# Altium schematic sheet I2C_Keypad_host_module_HID.SchDoc
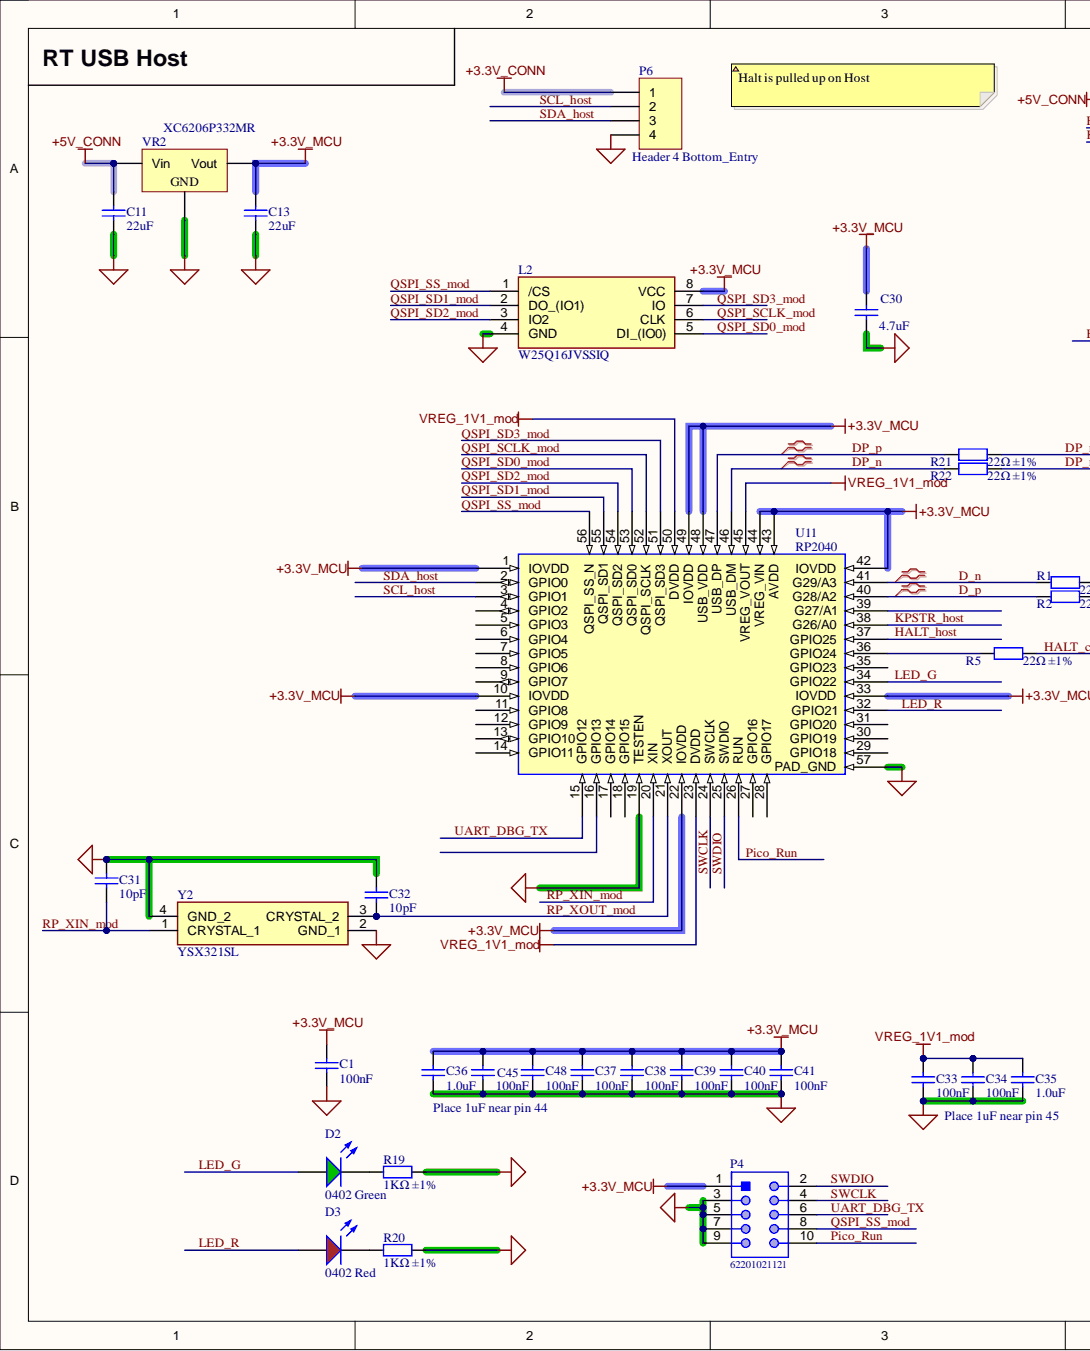
[diagram p0: I2C_Keypad_host_module_HID.SchDoc, left]
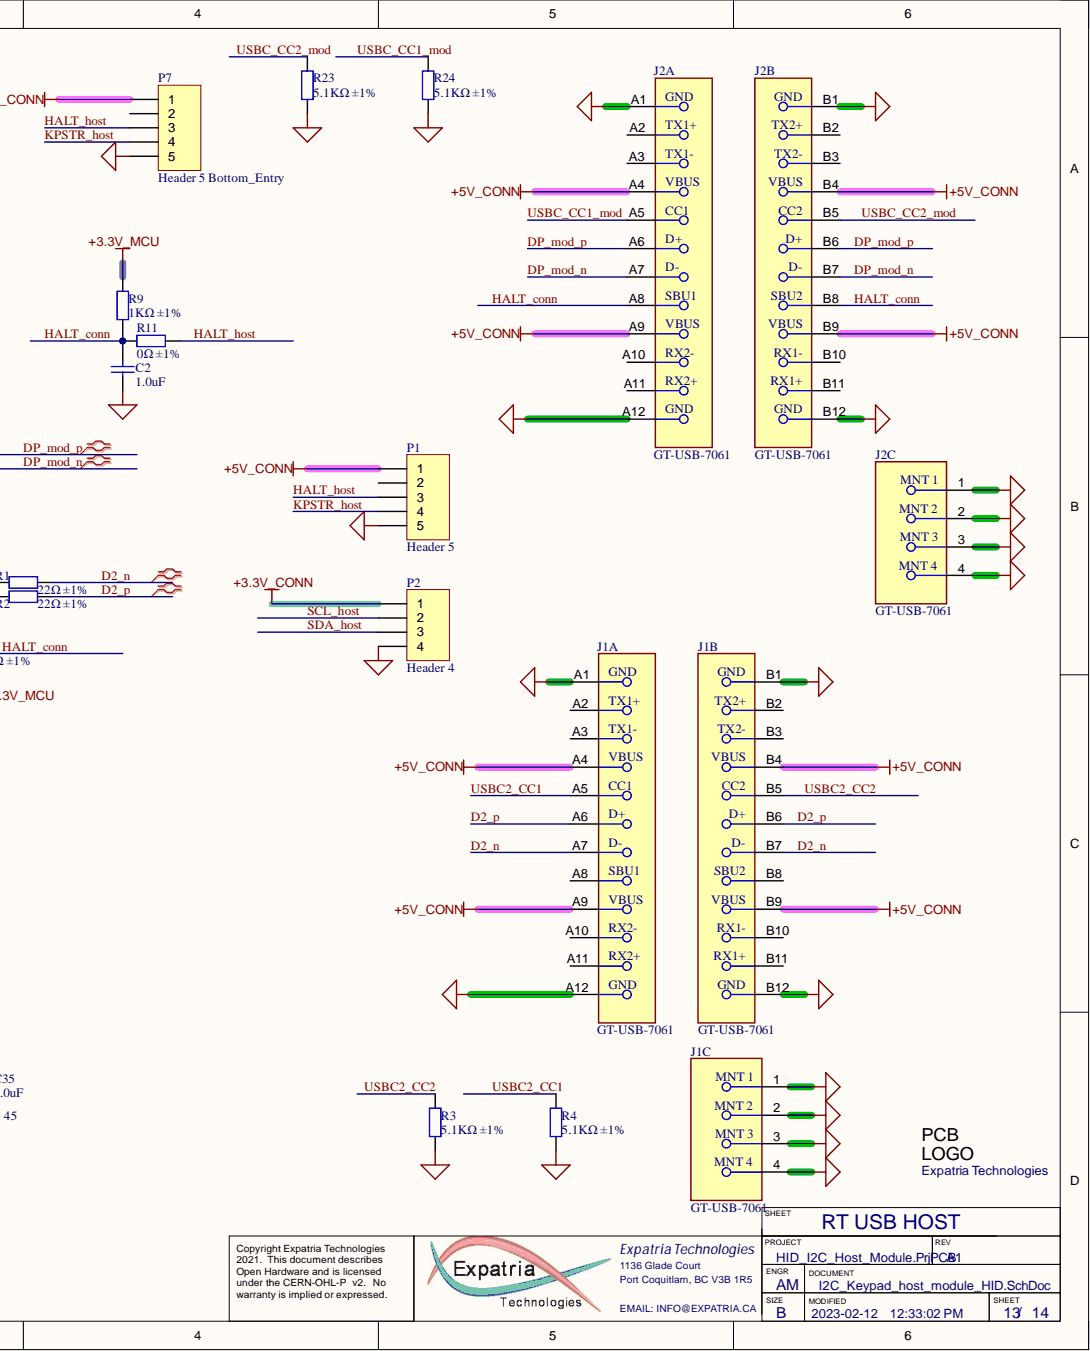
[diagram p1: I2C_Keypad_host_module_HID.SchDoc, right]

## Parts
C1  100nF  [0402_C]
C2  1.0uF  [0402_C]
C11  22uF  [0603_C]
C13  22uF  [0603_C]
C30  4.7uF  [0402_C]
C31  10pF  [0402_C]
C32  10pF  [0402_C]
C33  100nF  [0402_C]
C34  100nF  [0402_C]
C35  1.0uF  [0402_C]
C36  1.0uF  [0402_C]
C37  100nF  [0402_C]
C38  100nF  [0402_C]
C39  100nF  [0402_C]
C40  100nF  [0402_C]
C41  100nF  [0402_C]
C45  100nF  [0402_C]
C48  100nF  [0402_C]
D2  0402 Green  Color: Green  Package: 0402  [LED 0402_1005 RED]
D3  0402 Red  Color: Red  Package: 0402  [LED 0402_1005 RED]
J1  GT-USB-7061  [USB-C-SMD_GT-USB-7061]  (parts A,B,C)
J2  GT-USB-7061  [USB-C-SMD_GT-USB-7061]  (parts A,B,C)
L2  W25Q16JVSSIQ  [SOIC127P780X195-8N]
Logo1  Expatria Technologies  [Expatria-Logo]  (no BOM)
P1  Header 5  [HDR1X5]
P2  Header 4  [HDR1X4]
P4  62201021121  [62201021121]  (no BOM)
P6  Header 4 Bottom_Entry  [NPTC041KFXCRC]  (no BOM)
P7  Header 5 Bottom_Entry  [NPTC051KFXCRC]  (no BOM)
R1  22Ω ±1%  Resistance: 22Ω  [0402_R]
R2  22Ω ±1%  Resistance: 22Ω  [0402_R]
R3  5.1KΩ ±1%  [0402_R]
R4  5.1KΩ ±1%  [0402_R]
R5  22Ω ±1%  Resistance: 22Ω  [0402_R]
R9  1KΩ ±1%  Resistance: 1KΩ  [0402_R]
R11  0Ω ±1%  Resistance: 0Ω  [0402_R]  (no BOM)
R19  1KΩ ±1%  Resistance: 1KΩ  [0402_R]
R20  1KΩ ±1%  Resistance: 1KΩ  [0402_R]
R21  22Ω ±1%  Resistance: 22Ω  [0402_R]
R22  22Ω ±1%  Resistance: 22Ω  [0402_R]
R23  5.1KΩ ±1%  [0402_R]
R24  5.1KΩ ±1%  [0402_R]
U11  RP2040  [RP2040]
VR2  XC6206P332MR  [SOT-23-3L]
Y2  YSX321SL  [YSX321SL]

## Nets
+3.3V_CONN: P2.1 P6.1
+3.3V_MCU: C1.2 C13.2 C30.2 C36.2 C37.2 C38.2 C39.2 C40.2 C41.2 C45.2 C48.2 L2.8(VCC) P4.1 R9.2 U11.1(IOVDD) U11.10(IOVDD) U11.22(IOVDD) U11.33(IOVDD) U11.42(IOVDD) U11.43(AVDD) U11.44(VREG_VIN) U11.48(USB_VDD) U11.49(IOVDD) VR2.2
+5V_CONN: C11.2 J1.A4 J1.A9 J1.B4 J1.B9 J2.A4 J2.A9 J2.B4 J2.B9 P1.1 P7.1 VR2.3
GND: C1.1 C2.1 C11.1 C13.1 C30.1 C31.2 C32.2 C33.1 C34.1 C35.1 C36.1 C37.1 C38.1 C39.1 C40.1 C41.1 C45.1 C48.1 J1.1 J1.2 J1.3 J1.4 J1.A1 J1.A12 J1.B1 J1.B12 J2.1 J2.2 J2.3 J2.4 J2.A1 J2.A12 J2.B1 J2.B12 L2.4(GND) P1.5 P2.4 P4.3 P4.5 P4.7 P4.9 P6.4 P7.5 R3.2 R4.2 R19.2 R20.2 R23.2 R24.2 U11.19(TESTEN) U11.57(PAD_GND) VR2.1 Y2.2(GND_1) Y2.4(GND_2)
VREG_1V1_mod: C33.2 C34.2 C35.2 U11.23(DVDD) U11.45(VREG_VOUT) U11.50(DVDD)
D2_n: J1.A7 J1.B7 R1.1
D2_p: J1.A6 J1.B6 R2.1
D_n: R1.2 U11.41(G29/A3)
D_p: R2.2 U11.40(G28/A2)
DP_mod_n: J2.A7 J2.B7 R22.1
DP_mod_p: J2.A6 J2.B6 R21.1
DP_n: R22.2 U11.46(USB_DM)
DP_p: R21.2 U11.47(USB_DP)
HALT_conn: C2.2 J2.A8 J2.B8 R5.1 R9.1 R11.1
HALT_host: P1.3 P7.3 R11.2 U11.37(GPIO25)
KPSTR_host: P1.4 P7.4 U11.38(G26/A0)
LED_G: D2.A U11.34(GPIO22)
LED_R: D3.A U11.32(GPIO21)
Pico_Run: P4.10 U11.26(RUN)
QSPI_SCLK_mod: L2.6(CLK) U11.52(QSPI_SCLK)
QSPI_SD0_mod: L2.5(DI_(IO0)) U11.53(QSPI_SD0)
QSPI_SD1_mod: L2.2(DO_(IO1)) U11.55(QSPI_SD1)
QSPI_SD2_mod: L2.3(IO2) U11.54(QSPI_SD2)
QSPI_SD3_mod: L2.7(IO) U11.51(QSPI_SD3)
QSPI_SS_mod: L2.1(/CS) P4.8 U11.56(QSPI_SS_N)
RP_XIN_mod: C31.1 U11.20(XIN) Y2.1(CRYSTAL_1)
RP_XOUT_mod: C32.1 U11.21(XOUT) Y2.3(CRYSTAL_2)
SCL_host: P2.2 P6.2 U11.3(GPIO1)
SDA_host: P2.3 P6.3 U11.2(GPIO0)
SWCLK: P4.4 U11.24(SWCLK)
SWDIO: P4.2 U11.25(SWDIO)
UART_DBG_TX: P4.6 U11.15(GPIO12)
USBC2_CC1: J1.A5 R4.1
USBC2_CC2: J1.B5 R3.1
USBC_CC1_mod: J2.A5 R24.1
USBC_CC2_mod: J2.B5 R23.1
NetD2_K: D2.K R19.1
NetD3_K: D3.K R20.1
NetR5_2: R5.2 U11.36(GPIO24)

Not connected: J1 A2 A3 A8 A10 A11 B2 B3 B8 B10 B11; J2 A2 A3 A10 A11 B2 B3 B10 B11; P1 2; P7 2; U11 4(GPIO2) 5(GPIO3) 6(GPIO4) 7(GPIO5) 8(GPIO6) 9(GPIO7) 11(GPIO8) 12(GPIO9) 13(GPIO10) 14(GPIO11) 16(GPIO13) 17(GPIO14) 18(GPIO15) 27(GPIO16) 28(GPIO17) 29(GPIO18) 30(GPIO19) 31(GPIO20) 35(GPIO23) 39(G27/A1)

## Library data of the parts
C1 C33 C34 C37-C41 C45 C48 = 0402 100nF (104) 10% 16V: Chip capacitors; Manufacturer: Samsung Electro-Mechanics; PartNumber: CL05B104KO5NNNC; Tolerance: 10%; Voltage: 16V; Capacitance: 100nF; Component Kind: Standard; ComponentLink1Description: Supplier link; ComponentLink1URL: http://www.szlcsc.com/product/details_1877.html; Library Name: Cap.SchLib; Package: 0402; Supplier: LC; SuppliersPartNumber: C1525
C2 C35 C36 = 0402 1.0uF (105) 10% 25V: Chip capacitor; Manufacturer: Samsung Electro-Mechanics; PartNumber: CL05A105KA5NQNC; Capacitance: 1uF; Tolerance: 10%; Voltage: 25V; Component Kind: Standard; ComponentLink1Description: Supplier link; ComponentLink1URL: http://www.szlcsc.com/product/details_53938.html; Library Name: Cap.SchLib; Package: 0402; Supplier: LC; SuppliersPartNumber: C52923
C11 C13 = 0603 22uF (226) 20% 6.3V: Chip capacitors; Manufacturer: Samsung Electro-Mechanics; PartNumber: CL10A226MQ8NRNC; Tolerance: 20%; Voltage: 6.3V; Capacitance: 22uF; Component Kind: Standard; ComponentLink1Description: Supplier link; ComponentLink1URL: http://www.szlcsc.com/product/details_60514.html; Library Name: Cap.SchLib; Package: 0603; Supplier: LC; SuppliersPartNumber: C59461
C30 = 0402 4.7uF (475) 20% 10V: Chip capacitor; Manufacturer: Samsung Electro-Mechanics; PartNumber: CL05A475MP5NRNC; Tolerance: 20%; Voltage: 10V; Capacitance: 4.7uF; Component Kind: Standard; ComponentLink1Description: Supplier link; ComponentLink1URL: http://www.szlcsc.com/product/details_24469.html; Library Name: Cap.SchLib; Package: 0402; Supplier: LC; SuppliersPartNumber: C23733
C31 C32 = 0402 10pF (100) 5% 50V: Chip capacitors; Manufacturer: Samsung Electro-Mechanics; PartNumber: CL05C100JB5NNNC; Tolerance: 5%; Voltage: 50V; Capacitance: 10pF; Component Kind: Standard; ComponentLink1Description: Supplier link; ComponentLink1URL: http://www.szlcsc.com/product/details_33914.html; Library Name: Cap.SchLib; Package: 0402; Supplier: LC; SuppliersPartNumber: C32949
D2 = 0402 LED Green: LED; Component Kind: Standard; Library Name: LED.SchLib; Supplier: LC; SuppliersPartNumber: C130723
D3 = 0402 LED Red: LED; Component Kind: Standard; Library Name: LED.SchLib; Supplier: LC; SuppliersPartNumber: C130720
J1 J2 = USBC-GT-USB-7061: CONN RCP USB3.1 TYPEC 24P SMD VERT; Manufacturer: G-Switch; Component Kind: Standard; Manufacturer Part Number: GT-USB-7061; SuppliersPartNumber: C2762983; Library Name: I2C_Jog_Controller.SCHLIB
L2 = W25Q128JVSIQ_TR: SPI NOR FLASH 16Mbit; Manufacturer_Name: Winbond; Component Kind: Standard; Datasheet Link: http://www.winbond.com/resource-files/w25q128jv%20spi%20revc%2011162016.pdf; Height: 1.95mm; Manufacturer_Part_Number: W25Q16JVSSIQ; SuppliersPartNumber: C82317
Logo1 = SBT-Logo: Manufacturer 1: NONE; Value: Expatria Logo; Manufacturer Part Number 1: NONE; Library Name: PrintNC_GRBLHAL_Breakout.SCHLIB
P1 = Header 5: Header, 5-Pin; Component Kind: Standard; LatestRevisionDate: 17-Jul-2002; LatestRevisionNote: Re-released for DXP Platform.; Signal Integrity: Connector; SuppliersPartNumber: C124379; Library Name: PrintNC_GRBLHAL_Breakout.SCHLIB; Publisher: Altium Limited
P2 = Header 4: Header, 4-Pin; Component Kind: Standard; Signal Integrity: Connector; SuppliersPartNumber: C124378; Library Name: PrintNC_GRBLHAL_Breakout.SCHLIB; Publisher: Altium Limited
P4 = CMP-1502-00948-1: THT Vertical Pin Header WR-PHD, Pitch 1.27 mm, Dual Row, 10 pins; Manufacturer: Wurth Elektronik; Contact Resistance: 20mOhm; Gender: Male; Pin Number: 10; Pitch: 1.27mm; Rated Current: 1A; Withstanding Voltage: 500VAC; Working Voltage: 100VAC; Datasheet URL: https://katalog.we-online.de/em/datasheet/62201021121.pdf; Library Name: Altium Content Vault; Manufacturer URL: http://www.we-online.de; Mounting Technology: THT; Operating Temperature: -40to105degC; Orientation: Vertical; Package Reference: 62201021121; Packaging: Box; Part Number: 62201021121; Row Number: Dual; Publisher: WurthElektronik
P6 = Header 4: Header, 4-Pin; Manufacturer: Sullins; Manufacturer_Part_Number: NPTC041KFXCRC; Signal Integrity: Connector; Library Name: PrintNC_GRBLHAL_Breakout.SCHLIB; Publisher: Altium Limited
P7 = Header 5: Header, 5-Pin; Manufacturer: Sullins; Manufacturer_Part_Number: NPTC051KFXCRC; Signal Integrity: Connector; Library Name: PrintNC_GRBLHAL_Breakout.SCHLIB
R1 R2 R5 R21 R22 = 0402 22R (22R0) 1%: Chip resistor; Manufacturer: Uniroyal Elec; PartNumber: 0402WGF220JTCE; Power: 1/16W; Component Kind: Standard; ComponentLink1Description: Supplier link; ComponentLink1URL: http://www.szlcsc.com/product/details_25835.html; Library Name: RES.SchLib; Package: 0402; Supplier: LC; SuppliersPartNumber: C25092; Tolerance: 1%
R3 R4 R23 R24 = 0402 5.1K (5101) 1%: Chip resistor; Manufacturer: Uniroyal Elec; PartNumber: 0402WGF5101TCE; Power: 1/16W; Resistance: 51.1KΩ; Component Kind: Standard; ComponentLink1Description: Supplier link; ComponentLink1URL: http://www.szlcsc.com/product/details_26648.html; Library Name: RES.SchLib; Package: 0402; Supplier: LC; SuppliersPartNumber: C25905; Tolerance: 1%
R9 R19 R20 = 0402 1K (1001) 1%: Chip resistor; Manufacturer: Uniroyal Elec; PartNumber: 0402WGF1001TCE; Power: 1/16W; Component Kind: Standard; ComponentLink1Description: Supplier link; ComponentLink1URL: http://www.szlcsc.com/product/details_12256.html; Library Name: RES.SchLib; Package: 0402; Supplier: LC; SuppliersPartNumber: C11702; Tolerance: 1%
R11 = 0402 0R (0R0) 1%: Chip resistor; Manufacturer: Uniroyal Elec; PartNumber: 0402WGF0000TCE; Power: 1/16W; ComponentLink1Description: Supplier link; ComponentLink1URL: http://www.szlcsc.com/product/details_17853.html; Library Name: RES.SchLib; Package: 0402; Supplier: LC; SuppliersPartNumber: C17168; Tolerance: 1%
U11 = RP2040: RP2040 First MCU from RPI; Component Kind: Standard; Library Name: Universal_CNC_Breakout.SCHLIB
VR2 = SOT-23 XC6206P332MR: 200mA 680mV@(100mA) Fixed 3.3V~3.3V Positive 1 6V SOT-23-3L Linear Voltage Regulators (LDO) ROHS; Component Kind: Standard; ComponentLink1Description: 供应商链接; ComponentLink1URL: http://www.szlcsc.com/product/details_5896.html; Library Name: LDO.SchLib; Package: SOT-23; Supplier: LC; SuppliersPartNumber: C5446
Y2 = YSX321SL: Crystal or Oscillator; Manufacturer_Name: YXC; Component Kind: Standard; Datasheet Link: https://datasheet.lcsc.com/szlcsc/YSX321SL-30MHZ-10PF-8PPM_C70576.pdf; Height: 0.8mm; Manufacturer_Part_Number: YSX321SL; SuppliersPartNumber: C9002
